# Altium schematic sheet HDMI.SchDoc(HDMI)
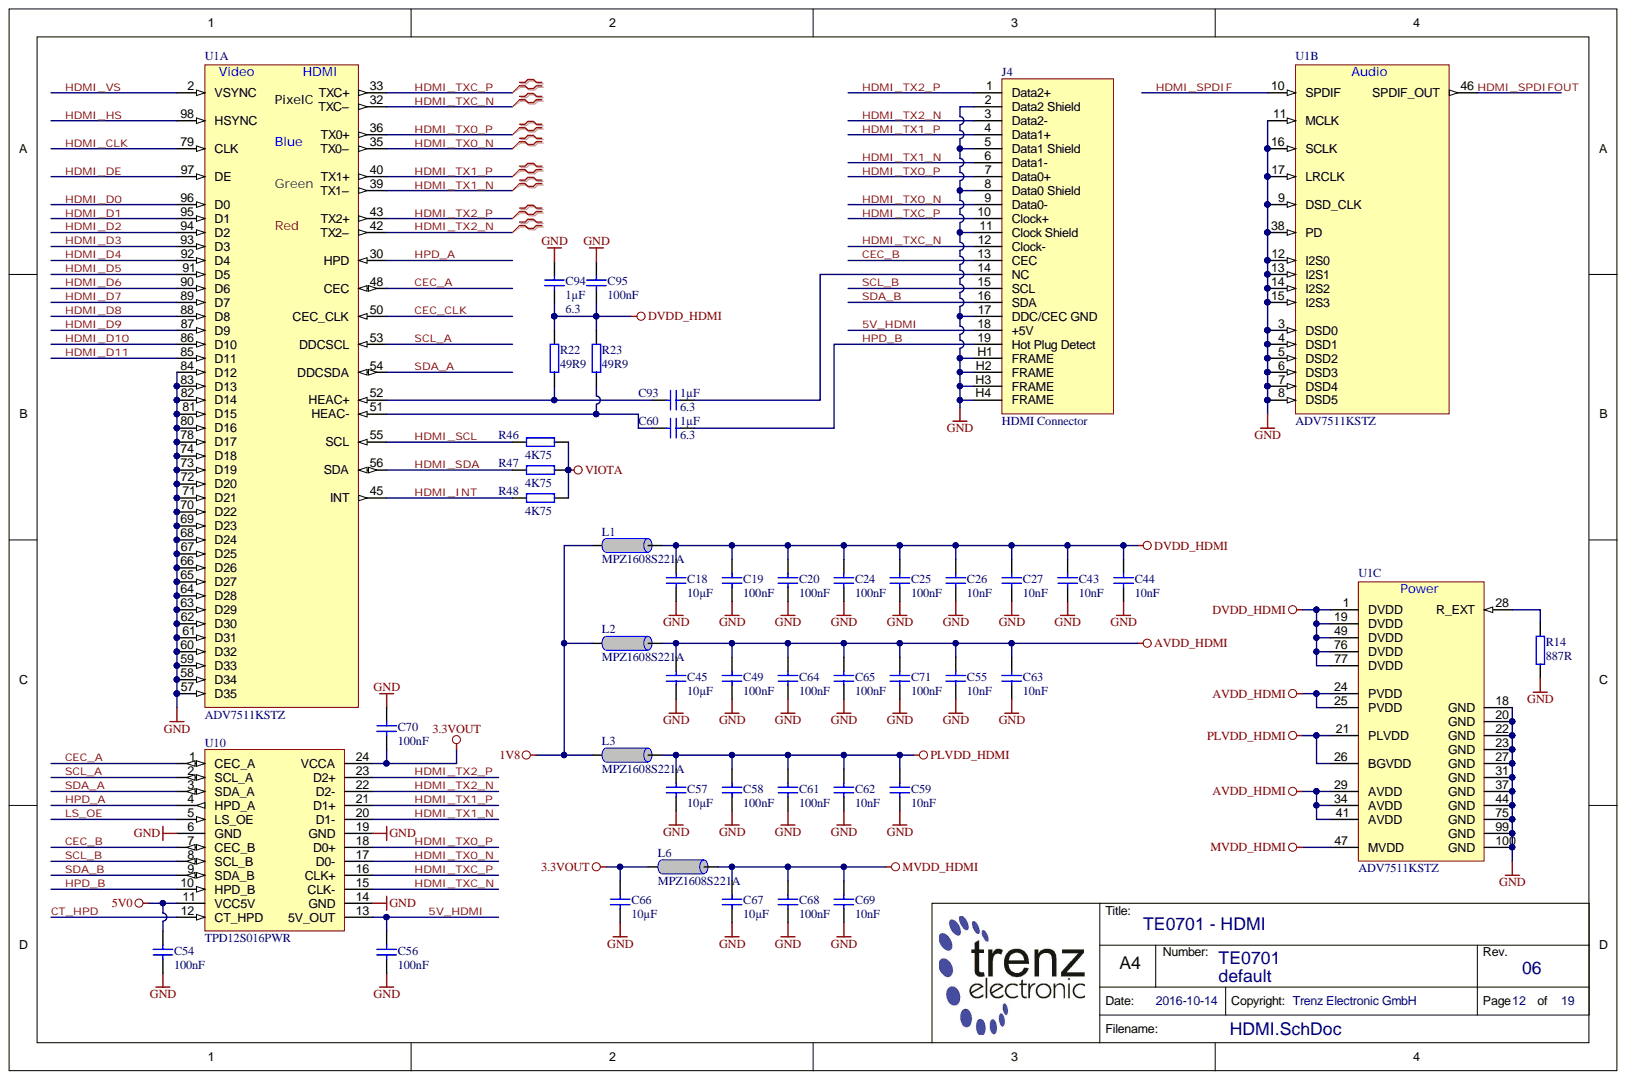
[diagram p0: HDMI.SchDoc]

## Parts
C18  10µF  [CAPC2012X135N]
C19  100nF  [CAPC1005X55N]
C20  100nF  [CAPC1005X55N]
C24  100nF  [CAPC1005X55N]
C25  100nF  [CAPC1005X55N]
C26  10nF  [CAPC1005X55N]
C27  10nF  [CAPC1005X55N]
C43  10nF  [CAPC1005X55N]
C44  10nF  [CAPC1005X55N]
C45  10µF  [CAPC2012X135N]
C49  100nF  [CAPC1005X55N]
C54  100nF  [CAPC1005X55N]
C55  10nF  [CAPC1005X55N]
C56  100nF  [CAPC1005X55N]
C57  10µF  [CAPC2012X135N]
C58  100nF  [CAPC1005X55N]
C59  10nF  [CAPC1005X55N]
C60  1µF  Voltage: 6.3  [CAPC1005X55N]
C61  100nF  [CAPC1005X55N]
C62  10nF  [CAPC1005X55N]
C63  10nF  [CAPC1005X55N]
C64  100nF  [CAPC1005X55N]
C65  100nF  [CAPC1005X55N]
C66  10µF  [CAPC2012X135N]
C67  10µF  [CAPC2012X135N]
C68  100nF  [CAPC1005X55N]
C69  10nF  [CAPC1005X55N]
C70  100nF  [CAPC1005X55N]
C71  100nF  [CAPC1005X55N]
C93  1µF  Voltage: 6.3  [CAPC1005X55N]
C94  1µF  Voltage: 6.3  [CAPC1005X55N]
C95  100nF  [CAPC1005X55N]
J4  HDMI Connector  Case: HDMI  [A35071TR-ND]
L1  MPZ1608S221A  [INDC1608X95N]
L2  MPZ1608S221A  [INDC1608X95N]
L3  MPZ1608S221A  [INDC1608X95N]
L6  MPZ1608S221A  [INDC1608X95N]
R14  887R  [RESC1005X40N]
R22  49R9  [RESC1005X40N]
R23  49R9  [RESC1005X40N]
R46  4K75  [RESC1005X40N]
R47  4K75  [RESC1005X40N]
R48  4K75  [RESC1005X40N]
U1  ADV7511KSTZ  [QFP50P1600X1600X160-100N]  (parts A,B,C)
U10  TPD12S016PWR  [SOP65P640X120-24N]

## Nets
1V8: L1.1 L2.1 L3.1
3.3VOUT: C66.2 C70.1 L6.1 U10.24(VCCA)
5V0: C54.1 U10.11(VCC5V)
AVDD_HDMI: C45.2 C49.1 C55.1 C63.1 C64.1 C65.1 C71.1 L2.2 U1.24(PVDD) U1.25(PVDD) U1.29(AVDD) U1.34(AVDD) U1.41(AVDD)
DVDD_HDMI: C18.2 C19.1 C20.1 C24.1 C25.1 C26.1 C27.1 C43.1 C44.1 C94.1 C95.1 L1.2 R22.1 R23.1 U1.1(DVDD) U1.19(DVDD) U1.49(DVDD) U1.76(DVDD) U1.77(DVDD)
GND: C18.1 C19.2 C20.2 C24.2 C25.2 C26.2 C27.2 C43.2 C44.2 C45.1 C49.2 C54.2 C55.2 C56.2 C57.1 C58.2 C59.2 C61.2 C62.2 C63.2 C64.2 C65.2 C66.1 C67.1 C68.2 C69.2 C70.2 C71.2 C94.2 C95.2 J4.2(Data2_Shield) J4.5(Data1_Shield) J4.8(Data0_Shield) J4.11(Clock_Shield) J4.17(DDC/CEC_GND) J4.H1(FRAME) J4.H2(FRAME) J4.H3(FRAME) J4.H4(FRAME) R14.2 U1.3(DSD0) U1.4(DSD1) U1.5(DSD2) U1.6(DSD3) U1.7(DSD4) U1.8(DSD5) U1.9(DSD_CLK) U1.11(MCLK) U1.12(I2S0) U1.13(I2S1) U1.14(I2S2) U1.15(I2S3) U1.16(SCLK) U1.17(LRCLK) U1.18(GND) U1.20(GND) U1.22(GND) U1.23(GND) U1.27(GND) U1.31(GND) U1.37(GND) U1.38(PD) U1.44(GND) U1.57(D35) U1.58(D34) U1.59(D33) U1.60(D32) U1.61(D31) U1.62(D30) U1.63(D29) U1.64(D28) U1.65(D27) U1.66(D26) U1.67(D25) U1.68(D24) U1.69(D23) U1.70(D22) U1.71(D21) U1.72(D20) U1.73(D19) U1.74(D18) U1.75(GND) U1.78(D17) U1.80(D16) U1.81(D15) U1.82(D14) U1.83(D13) U1.84(D12) U1.99(GND) U1.100(GND) U10.6(GND) U10.14(GND) U10.19(GND)
MVDD_HDMI: C67.2 C68.1 C69.1 L6.2 U1.47(MVDD)
PLVDD_HDMI: C57.2 C58.1 C59.1 C61.1 C62.1 L3.2 U1.21(PLVDD) U1.26(BGVDD)
VIOTA: R46.2 R47.2 R48.2
5V_HDMI: C56.1 J4.18(+5V) U10.13(5V_OUT)
CEC_A: U1.48(CEC) U10.1(CEC_A)
CEC_B: J4.13(CEC) U10.7(CEC_B)
CEC_CLK: U1.50(CEC_CLK)
CT_HPD: U10.12(CT_HPD)
HDMI_CLK: U1.79(CLK)
HDMI_D0: U1.96(D0)
HDMI_D1: U1.95(D1)
HDMI_D2: U1.94(D2)
HDMI_D3: U1.93(D3)
HDMI_D4: U1.92(D4)
HDMI_D5: U1.91(D5)
HDMI_D6: U1.90(D6)
HDMI_D7: U1.89(D7)
HDMI_D8: U1.88(D8)
HDMI_D9: U1.87(D9)
HDMI_D10: U1.86(D10)
HDMI_D11: U1.85(D11)
HDMI_DE: U1.97(DE)
HDMI_HS: U1.98(HSYNC)
HDMI_INT: R48.1 U1.45(INT)
HDMI_SCL: R46.1 U1.55(SCL)
HDMI_SDA: R47.1 U1.56(SDA)
HDMI_SPDIF: U1.10(SPDIF)
HDMI_SPDIFOUT: U1.46(SPDIF_OUT)
HDMI_TX0_N: J4.9(Data0-) U1.35(TX0–) U10.17(D0-)
HDMI_TX0_P: J4.7(Data0+) U1.36(TX0+) U10.18(D0+)
HDMI_TX1_N: J4.6(Data1-) U1.39(TX1–) U10.20(D1-)
HDMI_TX1_P: J4.4(Data1+) U1.40(TX1+) U10.21(D1+)
HDMI_TX2_N: J4.3(Data2-) U1.42(TX2–) U10.22(D2-)
HDMI_TX2_P: J4.1(Data2+) U1.43(TX2+) U10.23(D2+)
HDMI_TXC_N: J4.12(Clock-) U1.32(TXC–) U10.15(CLK-)
HDMI_TXC_P: J4.10(Clock+) U1.33(TXC+) U10.16(CLK+)
HDMI_VS: U1.2(VSYNC)
HPD_A: U1.30(HPD) U10.4(HPD_A)
HPD_B: C60.2 J4.19(Hot_Plug_Detect) U10.10(HPD_B)
LS_OE: U10.5(LS_OE)
SCL_A: U1.53(DDCSCL) U10.2(SCL_A)
SCL_B: J4.15(SCL) U10.8(SCL_B)
SDA_A: U1.54(DDCSDA) U10.3(SDA_A)
SDA_B: J4.16(SDA) U10.9(SDA_B)
NetC60_1: C60.1 R23.2 U1.51(HEAC-)
NetC93_1: C93.1 R22.2 U1.52(HEAC+)
NetC93_2: C93.2 J4.14(NC)
NetR14_1: R14.1 U1.28(R_EXT)

## Library data of the parts
C18 C45 C57 C66 C67 = 24380: KON 10µF 16V X7R SMD 10%; Database Table Name: C_2012_0805; Mixed: SMT; Preferred: Yes; Value: 10u; AN: 24380; Case: 2012 (0805); Component Kind: Standard; Library Name: TE.DbLib; Technology: X7R; Tolerance: 10%; Voltage: 16V
C19 C20 C24 C25 C49 C54 C56 C58 C61 C64 C65 C68 C70 C71 C95 = 22472: KON 100nF 25V X5R SMD; Database Table Name: C_1005_0402; Mixed: SMT; Preferred: Yes; Value: 100n; AN: 22472; Case: 1005 (0402); Component Kind: Standard; Library Name: TE.DbLib; Technology: X7R; Voltage: 25V
C26 C27 C43 C44 C55 C59 C62 C63 C69 = 22516: KON 10nF 50V X7R SMD 10%; Database Table Name: C_1005_0402; Mixed: SMT; Preferred: Yes; Value: 10n; Voltage: 16V; AN: 22516; Case: 1005 (0402); Component Kind: Standard; Library Name: TE.DbLib; Technology: X7R; Tolerance: 10%
C60 C93 C94 = 23453: KON 1µF 6.3V X5R SMD 10%; Database Table Name: C_1005_0402; Mixed: SMT; Preferred: Yes; Value: 1u; AN: 23453; Case: 1005 (0402); Component Kind: Standard; Library Name: TE.DbLib; Technology: X5R; Tolerance: 10%
J4 = 26642: HDMI Buchse 19Pol. 90°; Database Table Name: CON_IO; H-ART: 685119134923; Mixed: SMT; Trenz-Stock: Yes; AN: 26642; Component Kind: Standard; Library Name: TE.DbLib; HelpURL: B:\AD\adlib\datasheet\685119134923
L1-L3 L6 = 22718: Ferritdurchführung MPZ1608S221A; Database Table Name: L_1608_0603; Mixed: SMT; Preferred: Yes; Value: 220 OHm @ 100MHz; AN: 22718; Case: 1608 (0603); Component Kind: Standard; H-ART: MPZ1608S221A; Library Name: TE.DbLib; Trenz-Stock: Yes; HelpURL: B:\AD\adlib\datasheet\MPZ1608
R14 = 25128: WID SMD 887R 1% 0,063W; Database Table Name: R_1005_0402; Mixed: SMT; Value: 887; AN: 25128; Case: 1005 (0402); Component Kind: Standard; Library Name: TE.DbLib; Technology: TK100; Tolerance: 1%
R22 R23 = 23135: WID SMD 49R9 1% 0,063W; Database Table Name: R_1005_0402; Mixed: SMT; Preferred: Yes; Value: 49.9; AN: 23135; Case: 1005 (0402); Component Kind: Standard; Library Name: TE.DbLib; Technology: TK100; Tolerance: 1%
R46-R48 = 22853: WID SMD 4K75 1% 0,063W; Database Table Name: R_1005_0402; Mixed: SMT; Preferred: Yes; Value: 4.75K; AN: 22853; Case: 1005 (0402); Component Kind: Standard; Library Name: TE.DbLib; Technology: TK100; Tolerance: 1%
U1 = 25111: Database Table Name: VIDEO; Mixed: SMT; Trenz-Stock: Yes; AN: 25111; Case: LQFP100; Component Kind: Standard; Description: ADV7511KSTZ; H-ART: ADV7511KSTZ; Library Name: TE.DbLib; HelpURL: B:\AD\adlib\datasheet\ADV7511
U10 = 25112: Database Table Name: IF; Mixed: SMT; Trenz-Stock: Yes; AN: 25112; Case: TSSOP24; Component Kind: Standard; Description: TPD12S016PWR; H-ART: TPD12S016PWR; Library Name: TE.DbLib; HelpURL: B:\AD\adlib\datasheet\TPD12S016
